# Altium schematic sheet uC.SchDoc
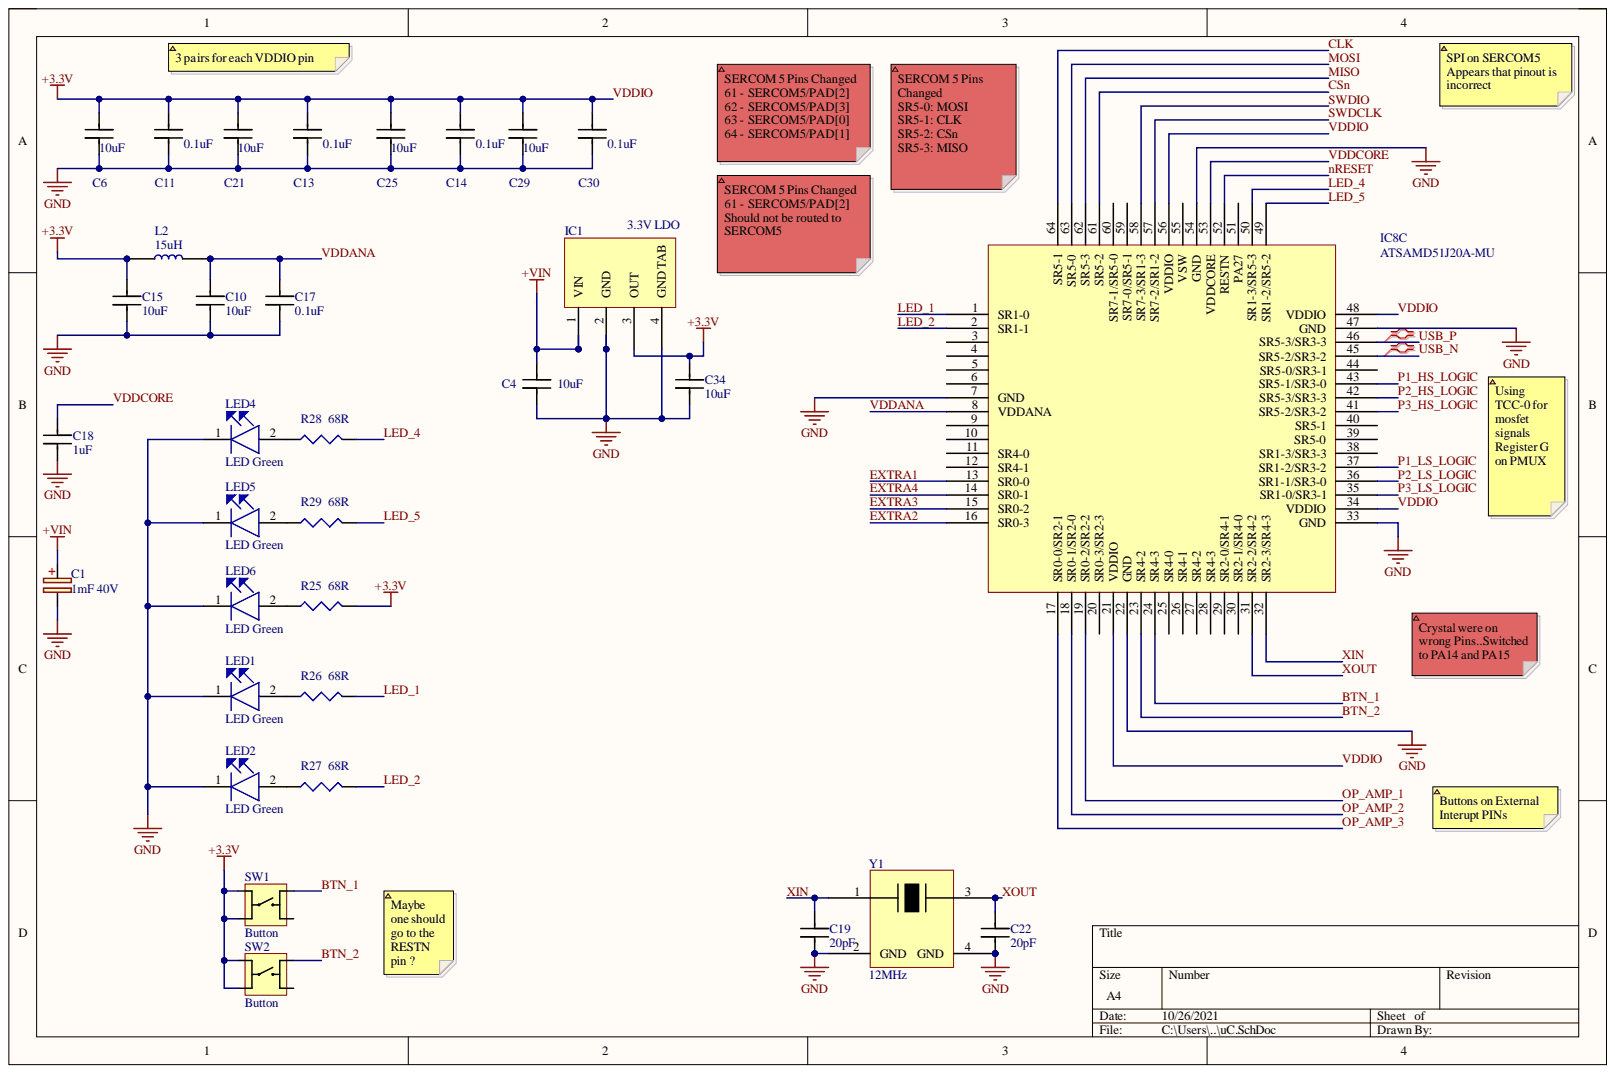
[diagram p0: uC.SchDoc]

## Parts
C1  1mF 40V  Capacitance: 1mF  [Capacitor_Axial_1mF]
C4  10uF  [Capacitor 0805]
C6  10uF  [Capacitor 0805]
C10  10uF  [Capacitor 0805]
C11  0.1uF  [0402 CAP CAPC1005X55N]
C13  0.1uF  [0402 CAP CAPC1005X55N]
C14  0.1uF  [0402 CAP CAPC1005X55N]
C15  10uF  [Capacitor 0805]
C17  0.1uF  [0402 CAP CAPC1005X55N]
C18  1uF  [0402 CAP CAPC1005X55N]
C19  20pF  [0402 CAP CAPC1005X55N]
C21  10uF  [Capacitor 0805]
C22  20pF  [0402 CAP CAPC1005X55N]
C25  10uF  [Capacitor 0805]
C29  10uF  [Capacitor 0805]
C30  0.1uF  [0402 CAP CAPC1005X55N]
C34  10uF  [Capacitor 0805]
IC1  3.3V LDO  Nominal Output Voltage: 3.3V  [TO229P990X238-4N]
IC8  ATSAMD51J20A-MU  [QFP50P1200X1200X120-64N]  (part C)
L2  15uH  [Inductor 0805]
LED1  LED Green  Illumination Color: Green  [LEDC1005X35N]
LED2  LED Green  Illumination Color: Green  [LEDC1005X35N]
LED4  LED Green  Illumination Color: Green  [LEDC1005X35N]
LED5  LED Green  Illumination Color: Green  [LEDC1005X35N]
LED6  LED Green  Illumination Color: Green  [LEDC1005X35N]
R25  68R  [0402_RES_RESC1005X40N]
R26  68R  [0402_RES_RESC1005X40N]
R27  68R  [0402_RES_RESC1005X40N]
R28  68R  [0402_RES_RESC1005X40N]
R29  68R  [0402_RES_RESC1005X40N]
SW1  Button  [SW_4-1437565-2]
SW2  Button  [SW_4-1437565-2]
Y1  12MHz  [OSC_Small_SC320X250X100N]

## Nets
+VIN: C1.1 C4.1 IC1.1(VIN)
GND: C1.2 C4.2 C6.2 C10.2 C11.2 C13.2 C14.2 C15.2 C17.1 C18.1 C19.1 C21.2 C22.1 C25.2 C29.2 C30.2 C34.2 IC1.2(GND) IC1.4(GND_TAB) IC8.7(GND) IC8.22(GND) IC8.33(GND) IC8.47(GND) IC8.54(GND) LED1.1 LED2.1 LED4.1 LED5.1 LED6.1 Y1.2(GND) Y1.4(GND)
BTN_1: IC8.24(SR4-3) SW1.3
BTN_2: IC8.23(SR4-2) SW2.3
CLK: IC8.64(SR5-1)
CSn: IC8.61(SR5-2)
EXTRA1: IC8.13(SR0-0)
EXTRA2: IC8.16(SR0-3)
EXTRA3: IC8.15(SR0-2)
EXTRA4: IC8.14(SR0-1)
LED_1: IC8.1(SR1-0) R26.2
LED_2: IC8.2(SR1-1) R27.2
LED_4: IC8.50(SR1-3/SR5-3) R28.2
LED_5: IC8.49(SR1-2/SR5-2) R29.2
MISO: IC8.62(SR5-3)
MOSI: IC8.63(SR5-0)
nRESET: IC8.52(RESTN)
OP_AMP_1: IC8.19(SR0-2/SR2-2)
OP_AMP_2: IC8.18(SR0-1/SR2-0)
OP_AMP_3: IC8.17(SR0-0/SR2-1)
P1_HS_LOGIC: IC8.43(SR5-1/SR3-0)
P1_LS_LOGIC: IC8.37(SR1-2/SR3-2)
P2_HS_LOGIC: IC8.42(SR5-3/SR3-3)
P2_LS_LOGIC: IC8.36(SR1-1/SR3-0)
P3_HS_LOGIC: IC8.41(SR5-2/SR3-2)
P3_LS_LOGIC: IC8.35(SR1-0/SR3-1)
SWDCLK: IC8.57(SR7-2/SR1-2)
SWDIO: IC8.58(SR7-3/SR1-3)
USB_N: IC8.45(SR5-2/SR3-2)
USB_P: IC8.46(SR5-3/SR3-3)
VDDANA: C10.1 C17.2 IC8.8(VDDANA) L2.2
VDDCORE: C18.2 IC8.53(VDDCORE)
VDDIO: C6.1 C11.1 C13.1 C14.1 C15.1 C21.1 C25.1 C29.1 C30.1 C34.1 IC1.3(OUT) IC8.21(VDDIO) IC8.34(VDDIO) IC8.48(VDDIO) IC8.56(VDDIO) L2.1 R25.2 SW1.1 SW1.2 SW2.1 SW2.2
XIN: C19.2 IC8.32(SR2-3/SR4-3) Y1.1
XOUT: C22.2 IC8.31(SR2-2/SR4-2) Y1.3
NetLED1_2: LED1.2 R26.1
NetLED2_2: LED2.2 R27.1
NetLED4_2: LED4.2 R28.1
NetLED5_2: LED5.2 R29.1
NetLED6_2: LED6.2 R25.1

Not connected: IC8 3 4 5 6 9 10 11(SR4-0) 12(SR4-1) 20(SR0-3/SR2-3) 25(SR4-0) 26(SR4-1) 27(SR4-2) 28(SR4-3) 29(SR2-0/SR4-1) 30(SR2-1/SR4-0) 38(SR1-3/SR3-3) 39(SR5-0) 40(SR5-1) 44(SR5-0/SR3-1) 51(PA27) 55(VSW) 59(SR7-0/SR5-1) 60(SR7-1/SR5-0); SW1 4; SW2 4

## Library data of the parts
C1 = MAL202117102E3: Cap Aluminum 1000uF 40V 20% (12.5 X 30mm) Axial 0.19 Ohm 900mA 8000 hr 85°C Bulk; Manufacturer 1: Vishay BCcomponents; Dielectric Material: Aluminium; ESR (Equivalent Series Resistance): 190mR; Impedance: 110mR; Lead Diameter: 0.8mm; Leakage Current: 84uA; Life (Hours): 8000Hour; Case/Package: Axial; Component Kind: Standard; ComponentLink1Description: https://datasheet.ciiva.com/117/021asm-117140.pdf?src-supplier=Digi-Key; ComponentLink1URL: https://datasheet.ciiva.com/117/021asm-117140.pdf?src-supplier=Digi-Key; ComponentLink2Description: https://datasheet.ciiva.com/7976/1756143-7976150.pdf?src-supplier=Element14; ComponentLink2URL: https://datasheet.ciiva.com/7976/1756143-7976150.pdf?src-supplier=Element14; ComponentLink3Description: https://datasheet.ciiva.com/28640/1912509-28640203.pdf?src-supplier=Element14; ComponentLink3URL: https://datasheet.ciiva.com/28640/1912509-28640203.pdf?src-supplier=Element14; ComponentLink4Description: https://datasheet.ciiva.com/46385/1912509-46385339.pdf?src-supplier=Element14; ComponentLink4URL: https://datasheet.ciiva.com/46385/1912509-46385339.pdf?src-supplier=Element14; ComponentLink5Description: https://datasheet.ciiva.com/25899/021asm-25899452.pdf?src-supplier=Avnet+Europe; ComponentLink5URL: https://datasheet.ciiva.com/25899/021asm-25899452.pdf?src-supplier=Avnet+Europe; ComponentLink6Description: https://datasheet.ciiva.com/11697/021asm-11697309.pdf?src-supplier=Avnet; ComponentLink6URL: https://datasheet.ciiva.com/11697/021asm-11697309.pdf?src-supplier=Avnet; ComponentLink7Description: https://datasheet.ciiva.com/5331/021asm-239741-5331278.pdf?src-supplier=Mouser; ComponentLink7URL: https://datasheet.ciiva.com/5331/021asm-239741-5331278.pdf?src-supplier=Mouser; Diameter: 12.5mm; Height: 30mm; Lead Pitch: 35mm; Length: 30mm; Library Name: Schlib1.SchLib; Manufacturer Part Number 1: MAL202117102E3; Max Operating Temperature: 85°C; Min Operating Temperature: -40°C; Mount: Through Hole,PCB; Package Quantity: 260; Packaging: Bulk; Pricing 1: 1=3,33000, 10=2,68800, 100=2,09630, 500=1,55876, 1000=1,46200 (USD); Radiation Hardening: No; REACH SVHC: Unknown; Ripple Current: 900mA; RoHS: Yes; Stock 1: 2787; Supplier 1: Digi-Key; Supplier Part Number 1: 4056PHBK-ND; Termination: Axial; Tolerance: 20%; Voltage: 40V; Voltage Rating: 40V; Voltage Rating (DC): 40V
C4 C6 C10 C15 C21 C25 C29 C34 = GMK212BBJ106KG-T: 10uF 35VDC 0805; Manufacturer 1: Taiyo Yuden; Tolerance: 10%; Voltage Rating: 35V; Capacitance: 10uF; Case Code (Imperial): 0805; Case Code (Metric): 2012; Case/Package: 0805; Component Kind: Standard; ComponentLink1Description: http://ds.yuden.co.jp/TYCOMPAS/ut/detail.do?productNo=GMK212BBJ106KG-T&fileName=GMK212BBJ106KG-T_SS&mode=specSheetDownload&src-supplier=Digi-Key; ComponentLink1URL: http://ds.yuden.co.jp/TYCOMPAS/ut/detail.do?productNo=GMK212BBJ106KG-T&fileName=GMK212BBJ106KG-T_SS&mode=specSheetDownload&src-supplier=Digi-Key; ComponentLink2Description: http://www.farnell.com/datasheets/2819844.pdf?src-supplier=Newark; ComponentLink2URL: http://www.farnell.com/datasheets/2819844.pdf?src-supplier=Newark; ComponentLink3Description: http://www.yuden.co.jp/productdata/catalog/mlcc06_e.pdf?src-supplier=Digi-Key; ComponentLink3URL: http://www.yuden.co.jp/productdata/catalog/mlcc06_e.pdf?src-supplier=Digi-Key; Lead Free: Lead Free; Library Name: Schlib1.SchLib; Manufacturer Part Number 1: GMK212BBJ106KG-T; Max Operating Temperature: 85°C; Min Operating Temperature: -55°C; Mount: Surface Mount; Packaging: Cut Tape; Pricing 1: 1=0,78000, 10=0,55200, 100=0,36380, 500=0,26946, 1000=0,22904 (USD); RoHS: No; Stock 1: 92799; Supplier 1: Digi-Key; Supplier Part Number 1: 587-4893-1-ND
C11 C13 C14 C17 C30 = C1005X7R1H104K050BB: CAP CER 0.1UF 50V X7R 0402; Manufacturer 1: TDK; Capacitance: 100nF; Dielectric Material: Ceramic; Tolerance: 10%; Case Code (Imperial): 0402; Case Code (Metric): 1005; Case/Package: 0402; Component Kind: Standard; ComponentLink1Description: https://datasheet.ciiva.com/16427/c1005x7r1h104k050bb-16427685.pdf?src-supplier=Future+Electronics; ComponentLink1URL: https://datasheet.ciiva.com/16427/c1005x7r1h104k050bb-16427685.pdf?src-supplier=Future+Electronics; ComponentLink2Description: https://datasheet.ciiva.com/26285/2983c1005x7r1h104k050bb-26285417.pdf?src-supplier=Onlinecomponents.com; ComponentLink2URL: https://datasheet.ciiva.com/26285/2983c1005x7r1h104k050bb-26285417.pdf?src-supplier=Onlinecomponents.com; ComponentLink3Description: https://datasheet.ciiva.com/22725/1913358-22725480.pdf?src-supplier=Element14; ComponentLink3URL: https://datasheet.ciiva.com/22725/1913358-22725480.pdf?src-supplier=Element14; ComponentLink4Description: https://datasheet.ciiva.com/6292/tdkmlcccaprange-6292410.pdf?src-supplier=Digi-Key; ComponentLink4URL: https://datasheet.ciiva.com/6292/tdkmlcccaprange-6292410.pdf?src-supplier=Digi-Key; ComponentLink5Description: https://datasheet.ciiva.com/22725/1913358-22725482.pdf?src-supplier=Element14; ComponentLink5URL: https://datasheet.ciiva.com/22725/1913358-22725482.pdf?src-supplier=Element14; ComponentLink6Description: https://datasheet.ciiva.com/48671/e412-c-48671294.pdf?src-supplier=Avnet; ComponentLink6URL: https://datasheet.ciiva.com/48671/e412-c-48671294.pdf?src-supplier=Avnet; ComponentLink7Description: https://datasheet.ciiva.com/17487/e412-c-17487596.pdf?src-supplier=Avnet+Europe; ComponentLink7URL: https://datasheet.ciiva.com/17487/e412-c-17487596.pdf?src-supplier=Avnet+Europe; ComponentLink8Description: https://datasheet.ciiva.com/23802/1796654-23802749.pdf?src-supplier=Newark; ComponentLink8URL: https://datasheet.ciiva.com/23802/1796654-23802749.pdf?src-supplier=Newark; ComponentLink9Description: https://datasheet.ciiva.com/6292/tdkmlcccaprange-6292415.pdf?src-supplier=Digi-Key; ComponentLink9URL: https://datasheet.ciiva.com/6292/tdkmlcccaprange-6292415.pdf?src-supplier=Digi-Key; Composition: Ceramic; Depth: 0.5mm; Dielectric: X7R; Height: 0.5mm; Lead Free: Lead Free; Length: 1mm; Library Name: Schlib1.SchLib; Manufacturer Part Number 1: C1005X7R1H104K050BB; Max Operating Temperature: 125°C; Min Operating Temperature: -55°C; Mount: Surface Mount; Package Quantity: 1; Packaging: Tape and Reel; Pricing 1: 1=0.12000, 10=0.08300, 100=0.03910, 500=0.02742, 1000=0.02269, 2500=0.02112, 5000=0.01932 (USD); Radiation Hardening: No; REACH SVHC: No SVHC; RoHS: Yes; Stock 1: 313215; Supplier 1: Digi-Key; Termination: SMD/SMT; Thickness: 0.022inch; Voltage: 50V; Voltage Rating: 50V; Voltage Rating (DC): 50V; Weight: 2.3E-05oz; Width: 0.5mm
C18 = C1005X6S1C105K050BC: CERAMIC CAPACITOR, 1UF, 16V, X6S, 10%, 0402, FULL REEL; Product Range:C Series; Capacitance:1 F; Capacitance Tolerance: 10%; Dielectric Characteristic:X6S; Voltage Rating:16V; Ceramic Capacitor Case:0402 [1005 Metric]; MSL:- ;RoHS Compliant: Yes; Manufacturer 1: TDK; Capacitance: 1uF; Case Code (Imperial): 0402; Case Code (Metric): 1005; Case/Package: 0402; Component Kind: Standard; ComponentLink1Description: https://datasheet.ciiva.com/6259/tdkmlcccaprange-6259397.pdf?src-supplier=Digi-Key; ComponentLink1URL: https://datasheet.ciiva.com/6259/tdkmlcccaprange-6259397.pdf?src-supplier=Digi-Key; ComponentLink2Description: https://datasheet.ciiva.com/6259/tdkmlcccaprange-6259389.pdf?src-supplier=Digi-Key; ComponentLink2URL: https://datasheet.ciiva.com/6259/tdkmlcccaprange-6259389.pdf?src-supplier=Digi-Key; Composition: Ceramic; Depth: 0.5mm; Dielectric: X6S; Dielectric Material: Ceramic; Height: 0.5mm; Lead Free: Lead Free; Length: 1mm; Library Name: Schlib1.SchLib; Manufacturer Part Number 1: C1005X6S1C105K050BC; Max Operating Temperature: 105°C; Min Operating Temperature: -55°C; Mount: Surface Mount; Package Quantity: 1; Packaging: Tape and Reel; Pricing 1: 10000=0.58100, 50000=0.56800 (EUR); REACH SVHC: No SVHC; RoHS: Yes; Stock 1: 170000; Supplier 1: Farnell; Termination: SMD/SMT; Thickness: 0.022inch; Tolerance: 10%; Voltage Rating: 16V; Voltage Rating (DC): 16V; Weight: 2.3E-05oz; Width: 0.02inch
C19 C22 = GJM1555C1H200FB01D: CAP CER 20PF 50V C0G/NP0 0402; Manufacturer 1: Murata; Dielectric: C0G; Tolerance: 1%; Capacitance: 20pF; Case Code (Imperial): 0402; Case Code (Metric): 1005; Case/Package: 0402; Component Kind: Standard; ComponentLink1Description: http://www.mouser.com/ds/2/281/c02e-2905.pdf?src-supplier=Mouser; ComponentLink1URL: http://www.mouser.com/ds/2/281/c02e-2905.pdf?src-supplier=Mouser; ComponentLink2Description: http://www.farnell.com/datasheets/2867762.pdf?src-supplier=Farnell; ComponentLink2URL: http://www.farnell.com/datasheets/2867762.pdf?src-supplier=Farnell; ComponentLink3Description: http://www.farnell.com/datasheets/2867762.pdf?src-supplier=Element14; ComponentLink3URL: http://www.farnell.com/datasheets/2867762.pdf?src-supplier=Element14; ComponentLink4Description: http://search.murata.co.jp/Ceramy/image/img/A01X/G101/ENG/GJM1555C1H200FB01-01.pdf?src-supplier=Digi-Key; ComponentLink4URL: http://search.murata.co.jp/Ceramy/image/img/A01X/G101/ENG/GJM1555C1H200FB01-01.pdf?src-supplier=Digi-Key; ComponentLink5Description: http://www.murata.com/products/catalog/pdf/c02e.pdf?src-supplier=Avnet; ComponentLink5URL: http://www.murata.com/products/catalog/pdf/c02e.pdf?src-supplier=Avnet; Depth: 0.5mm; Height: 0.5mm; Length: 1mm; Library Name: Schlib1.SchLib; Manufacturer Part Number 1: GJM1555C1H200FB01D; Max Operating Temperature: 125°C; Min Operating Temperature: -55°C; Mount: Surface Mount; Package Quantity: 10000; Packaging: Tape and Reel; Pricing 1: 1=0.28000, 10=0.19300, 100=0.09760, 500=0.06678, 1000=0.05908, 2500=0.05651, 5000=0.05137 (USD); Radiation Hardening: No; RoHS: Yes; Stock 1: 6961; Supplier 1: Digi-Key; Termination: SMD/SMT; Thickness: 0.022inch; Voltage Rating: 50V; Voltage Rating (DC): 50V; Width: 0.5mm
IC1 = TLF80511TFV33ATMA1: IC REG LINEAR 3.3V 400MA TO252-3; Manufacturer 1: Infineon; Accuracy: 2%; Dropout Voltage: 130mV; Max Input Voltage: 40V; Max Supply Voltage: 45V; Min Current Limit: 401mA; Number of Outputs: 1; Output Type: Fixed; Polarity: Positive; Quiescent Current: 38uA; Watchdog Timer: No; Case/Package: TO-252-3; Component Kind: Standard; ComponentLink1Description: http://www.farnell.com/datasheets/2211687.pdf?src-supplier=Farnell; ComponentLink1URL: http://www.farnell.com/datasheets/2211687.pdf?src-supplier=Farnell; ComponentLink2Description: http://www.infineon.com/dgdl/Infineon-TLF80511TF-DS-v01_00-EN.pdf?fileId=5546d46259d9a4bf0159fa3199ac3f4b&src-supplier=Digi-Key; ComponentLink2URL: http://www.infineon.com/dgdl/Infineon-TLF80511TF-DS-v01_00-EN.pdf?fileId=5546d46259d9a4bf0159fa3199ac3f4b&src-supplier=Digi-Key; ComponentLink3Description: https://www.infineon.com/dgdl/Infineon-TLF80511TF-DS-v01_00-EN.pdf?fileId=5546d46259d9a4bf0159fa3199ac3f4b&src-supplier=Digi-Key; ComponentLink3URL: https://www.infineon.com/dgdl/Infineon-TLF80511TF-DS-v01_00-EN.pdf?fileId=5546d46259d9a4bf0159fa3199ac3f4b&src-supplier=Digi-Key; ComponentLink4Description: http://www.infineon.com/dgdl/TLF80511TF-DS-10-Infineon.pdf?folderId=db3a30431ddc9372011e26863f92474e&fileId=db3a304344134c7a01441b9f99e51a7d&ack=t&src-supplier=Avnet; ComponentLink4URL: http://www.infineon.com/dgdl/TLF80511TF-DS-10-Infineon.pdf?folderId=db3a30431ddc9372011e26863f92474e&fileId=db3a304344134c7a01441b9f99e51a7d&ack=t&src-supplier=Avnet; Halogen Free: Halogen Free; Lead Free: Contains Lead; Library Name: Schlib1.SchLib; Manufacturer Part Number 1: TLF80511TFV33ATMA1; Max Operating Temperature: 150°C; Max Output Current: 400mA; Min Operating Temperature: -40°C; Mount: Surface Mount; Number of Pins: 3; Number of Regulators: 1; Output Current: 400mA; Output Voltage: 3.3V; Packaging: Tape and Reel; Pricing 1: 1=1,32000, 10=1,17700, 100=0,91790, 500=0,75830, 1000=0,59865 (USD); RoHS: Yes; Stock 1: 2160; Supplier 1: Digi-Key; Supplier Part Number 1: TLF80511TFV33ATMA1CT-ND
IC8 = ATSAMD51J20A-MU: ATSAMD51J20A-MU -  ARM MCU, SAM32 Family SAM D5X Series Microcontrollers, ARM Cortex-M4F, 32bitů, 120 MHz, 1 MB; Component Kind: Standard; Library Name: Schlib1.SchLib
L2 = MLZ2012M150WTD25: Fixed Ind 15uh 250ma 1.235 Ohm; MF: TDK; Availability: Unavailable; Component Kind: Standard; Library Name: Schlib1.SchLib; MP: MLZ2012M150WTD25; Package: 0805 TDK; Price: None; SnapEDA_Link: https://www.snapeda.com/parts/MLZ2012M150WTD25/TDK-Lambda%20Americas%20Inc/view-part/?ref=snap
LED1 LED2 LED4-LED6 = VLMG1500-GS08: VISHAY         VLMG1500-GS08             LED, YELLOW GREEN, 35MCD, 0402  2V 20mA 571nm; Color: Green,Yellow; Forward Current: 30mA; Lens Color: Clear; Lens Style: Rectangular; Number of Elements: 1; Power Dissipation: 75mW; Reverse Voltage: 5V; Viewing Angle: 130°; Case Code (Imperial): 0402; Case Code (Metric): 1005; Case/Package: 0402; Component Kind: Standard; ComponentLink1Description: https://datasheet.ciiva.com/13945/vlmo1500-13945360.pdf?src-supplier=Digi-Key; ComponentLink1URL: https://datasheet.ciiva.com/13945/vlmo1500-13945360.pdf?src-supplier=Digi-Key; ComponentLink2Description: https://datasheet.ciiva.com/12071/vlmo1500-244705-12071911.pdf?src-supplier=Mouser; ComponentLink2URL: https://datasheet.ciiva.com/12071/vlmo1500-244705-12071911.pdf?src-supplier=Mouser; ComponentLink3Description: https://datasheet.ciiva.com/13945/vlmo1500-13945358.pdf?src-supplier=Digi-Key; ComponentLink3URL: https://datasheet.ciiva.com/13945/vlmo1500-13945358.pdf?src-supplier=Digi-Key; ComponentLink4Description: https://datasheet.ciiva.com/30005/vlmo1500-30005134.pdf?src-supplier=Avnet; ComponentLink4URL: https://datasheet.ciiva.com/30005/vlmo1500-30005134.pdf?src-supplier=Avnet; ComponentLink5Description: https://datasheet.ciiva.com/13945/vlmo1500-13945359.pdf?src-supplier=Digi-Key; ComponentLink5URL: https://datasheet.ciiva.com/13945/vlmo1500-13945359.pdf?src-supplier=Digi-Key; Depth: 0.5mm; Dominant Wavelength: 571nm; Forward Voltage: 2V; Height: 0.35mm; Lead Free: Lead Free; Length: 1mm; Library Name: Schlib1.SchLib; Luminous Intensity: 35mcd; Manufacturer 1: Vishay; Manufacturer Part Number 1: VLMG1500-GS08; Max Operating Temperature: 85°C; Min Operating Temperature: -30°C; Mount: Surface Mount; Number of LEDs: 1; Number of Pins: 2; Package Quantity: 1; Packaging: Tape and Reel; Power Rating: 75mW; Pricing 1: 5=9.08800, 25=8.64300, 100=3.87600, 250=3.77100, 500=2.72400, 1000=1.83600 (EUR); Radiation Hardening: No; REACH SVHC: Unknown; RoHS: Yes; Stock 1: 14416; Supplier 1: Farnell; Test Current: 20mA; Wavelength: 571nm; Width: 0.5mm
R25-R29 = RC0402JR-07100RL: RES SMD 100 OHM 5% 1/16W 0402; Manufacturer 1: Yageo; Max Power Dissipation: 63mW; Resistance: 100R; Temperature Coefficient: 100ppm/°C; Voltage Rating: 50V; Case Code (Imperial): 0402; Case Code (Metric): 1005; Case/Package: 0402; Component Kind: Standard; ComponentLink1Description: https://datasheet.ciiva.com/2452/pyu-r-int-thick-7-2452619.pdf?src-supplier=Digi-Key; ComponentLink1URL: https://datasheet.ciiva.com/2452/pyu-r-int-thick-7-2452619.pdf?src-supplier=Digi-Key; ComponentLink2Description: https://datasheet.ciiva.com/16740/pyu-rc0402-51-rohs-l-6-r-16740924.pdf?src-supplier=Future+Electronics; ComponentLink2URL: https://datasheet.ciiva.com/16740/pyu-rc0402-51-rohs-l-6-r-16740924.pdf?src-supplier=Future+Electronics; ComponentLink3Description: https://datasheet.ciiva.com/12577/pyu-rc0402-51-rohs-l-6-r-12577725.pdf?src-supplier=Mouser; ComponentLink3URL: https://datasheet.ciiva.com/12577/pyu-rc0402-51-rohs-l-6-r-12577725.pdf?src-supplier=Mouser; ComponentLink4Description: https://datasheet.ciiva.com/2452/pyu-r-int-thick-7-2452617.pdf?src-supplier=Digi-Key; ComponentLink4URL: https://datasheet.ciiva.com/2452/pyu-r-int-thick-7-2452617.pdf?src-supplier=Digi-Key; ComponentLink5Description: https://datasheet.ciiva.com/46394/1018594-46394943.pdf?src-supplier=Element14; ComponentLink5URL: https://datasheet.ciiva.com/46394/1018594-46394943.pdf?src-supplier=Element14; ComponentLink6Description: https://datasheet.ciiva.com/7850/1018594-7850134.pdf?src-supplier=Element14; ComponentLink6URL: https://datasheet.ciiva.com/7850/1018594-7850134.pdf?src-supplier=Element14; ComponentLink7Description: https://datasheet.ciiva.com/7850/1018594-7850135.pdf?src-supplier=Element14; ComponentLink7URL: https://datasheet.ciiva.com/7850/1018594-7850135.pdf?src-supplier=Element14; ComponentLink8Description: https://datasheet.ciiva.com/2452/pyu-r-int-thick-7-2452618.pdf?src-supplier=Digi-Key; ComponentLink8URL: https://datasheet.ciiva.com/2452/pyu-r-int-thick-7-2452618.pdf?src-supplier=Digi-Key; Composition: Thick Film; Depth: 0.5mm; Features: Moisture Resistant; Height: 0.016inch; Lead Free: Lead Free; Length: 1mm; Library Name: Schlib1.SchLib; Manufacturer Part Number 1: RC0402JR-07100RL; Max Operating Temperature: 155°C; Military Standard: Not; Min Operating Temperature: -55°C; Number of Terminations: 2; Package Quantity: 1; Packaging: Tape and Reel; Power Rating: 63mW; Pricing 1: 1=0,10000, 10=0,01300, 100=0,00530, 1000=0,00236, 2500=0,00205, 5000=0,00169 (USD); REACH SVHC: No SVHC; RoHS: Yes; Stock 1: 5850295; Supplier 1: Digi-Key; Supplier Part Number 1: 311-100JRCT-ND; Termination: SMD/SMT; Tolerance: 5%; Weight: 2.3E-05oz; Width: 0.02inch
SW1 SW2 = FSM4JSMATR: TE CONNECTIVITY / ALCOSWITCH         4-1437565-2             Tactile Switch, Non Illuminated, 24 V, 50 mA, 160 gf, Solder; Manufacturer 1: TE Connectivity Alcoswitch; Connector Type: SMD/SMT; Contact Current Rating: 50mA; Max Voltage Rating (DC): 24V; Operating Force: 1.57N; Throw Configuration: SPST; Voltage Rating (DC): 12V; Case/Package: SMD/SMT; Component Kind: Standard; ComponentLink1Description: https://datasheet.ciiva.com/5801/eng-cd-2-1437565-7-u2-5801346.pdf?src-supplier=Farnell; ComponentLink1URL: https://datasheet.ciiva.com/5801/eng-cd-2-1437565-7-u2-5801346.pdf?src-supplier=Farnell; ComponentLink2Description: https://datasheet.ciiva.com/16320/eng-cs-1308111-1-switches-core-program-catalog-0308-16320065.pdf?src-supplier=Future+Electronics; ComponentLink2URL: https://datasheet.ciiva.com/16320/eng-cs-1308111-1-switches-core-program-catalog-0308-16320065.pdf?src-supplier=Future+Electronics; ComponentLink3Description: https://datasheet.ciiva.com/1530/1683375-1530944.pdf?src-supplier=Element14; ComponentLink3URL: https://datasheet.ciiva.com/1530/1683375-1530944.pdf?src-supplier=Element14; ComponentLink4Description: https://datasheet.ciiva.com/23765/1486934-23765878.pdf?src-supplier=Newark; ComponentLink4URL: https://datasheet.ciiva.com/23765/1486934-23765878.pdf?src-supplier=Newark; ComponentLink5Description: https://datasheet.ciiva.com/7638/921681-7638037.pdf?src-supplier=Element14; ComponentLink5URL: https://datasheet.ciiva.com/7638/921681-7638037.pdf?src-supplier=Element14; ComponentLink6Description: https://datasheet.ciiva.com/25892/eng-cs-1654270-2-0807-25892110.pdf?src-supplier=Avnet+Europe; ComponentLink6URL: https://datasheet.ciiva.com/25892/eng-cs-1654270-2-0807-25892110.pdf?src-supplier=Avnet+Europe; Contact Material: Copper,Silver,Copper Alloy; Contact Plating: Silver; Depth: 10mm; ELV: Compliant; Illumination Type: Illuminated; Lead Free: Lead Free; Length: 6mm; Library Name: Schlib1.SchLib; Manufacturer Part Number 1: FSM4JSMATR; Max Current Rating: 50mA; Max Operating Temperature: 85°C; Min Operating Temperature: -20°C; Mount: Surface Mount; Orientation: Straight; Packaging: Tape and Reel; Pricing 1: 1=0.33000, 10=0.31700, 25=0.30520, 50=0.29400, 100=0.28270, 250=0.26008, 500=0.22616 (USD); Radiation Hardening: No; REACH SVHC: Unknown; RoHS: Yes; Stock 1: 22288; Supplier 1: Digi-Key; Termination: Gull Wing
Y1 = ABM8-12.000MHZ-20-B1U-T: Manufacturer 1: Abracon; Component Kind: Standard; Library Name: Schlib1.SchLib; Manufacturer Part Number 1: ABM8-12.000MHZ-20-B1U-T; Pricing 1: 1=0.57000, 10=0.47300, 50=0.42580, 100=0.37840, 500=0.35948 (USD); RoHS: No; Stock 1: 756; Supplier 1: Digi-Key
